# Altium schematic sheet Hall Effect IC.SchDoc
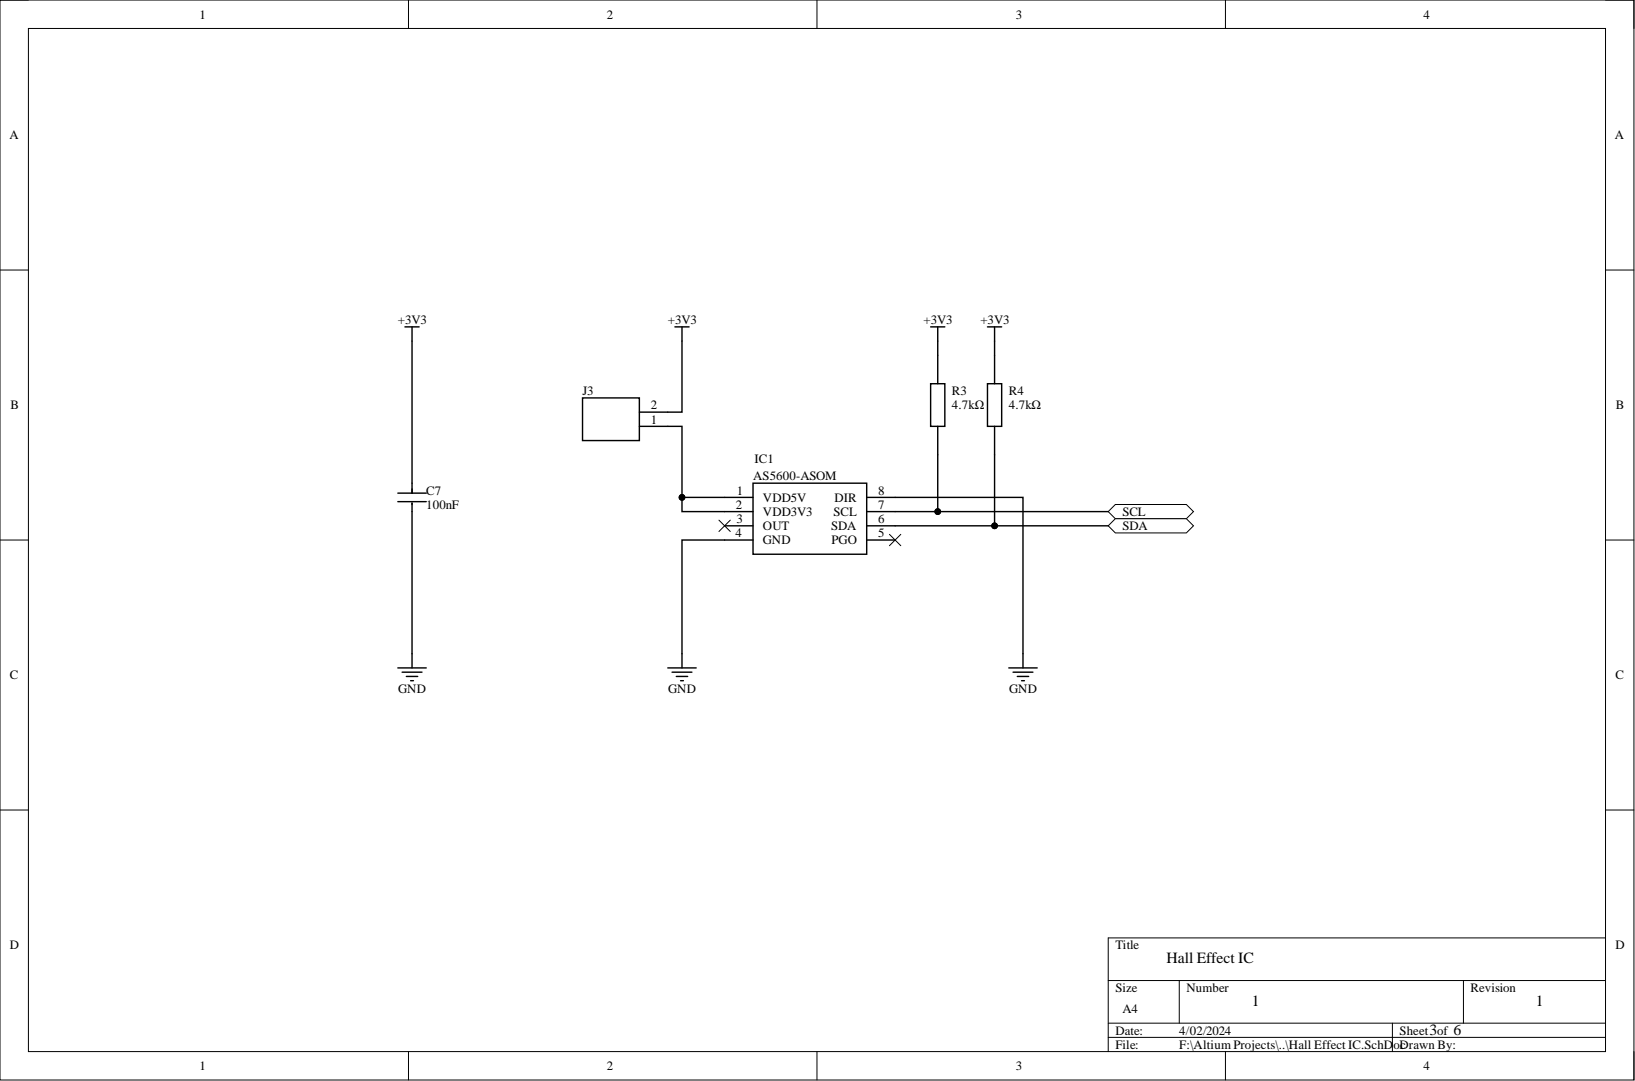
[diagram p0: Hall Effect IC.SchDoc]

## Parts
C7  value 100nF  [FP-C1206C-EB-MFG]  (hidden comment: C1206C104K5RAC7210)
IC1  AS5600-ASOM  [SOIC127P600X175-8N]
J3  87527-2  [HDRV2W66P0X254_1X2_467X244X1044P]  (value not drawn)
R3  value 4.7kΩ  [RESC3116X65N]  (hidden comment: CRCW12064K70FKEAHP)
R4  value 4.7kΩ  [RESC3116X65N]  (hidden comment: CRCW12064K70FKEAHP)

## Ports
SCL, SDA

## Nets
+3V3: C7.1 J3.2 R3.2 R4.2
GND: C7.2 IC1.4(GND) IC1.8(DIR)
SCL: IC1.7(SCL) R3.1 ; port SCL
SDA: IC1.6(SDA) R4.1 ; port SDA
NetIC1_1: IC1.1(VDD5V) IC1.2(VDD3V3) J3.1
NetIC1_3: IC1.3(OUT)
NetIC1_5: IC1.5(PGO)

## Library data of the parts
C7 = CMP-03020-003377-1: CAP CER 0.1UF 50V X7R 1206; Manufacturer: KEMET; Automotive Grade: Grade 0; Capacitance: 0.10 μF; Material: Ceramic; Temperature Coefficient: 15%; Tolerance: 0.1; Automotive: Yes; Category: Cap; Component Kind: Standard; ComponentLink2Description: Datasheet; ComponentLink2URL: https://content.kemet.com/datasheets/KEM_C1007_X8R_ULTRA_150C_SMD.pdf; Device Class L1: Passive Components; Device Class L2: Capacitors; Device Class L3: Ceramic Capacitors; Digikey Description: CAP CER 0.1UF 50V X7R 1206; Height: 0.88mm; Ipc Land Pattern Name: CAPC320160X78; Lead Free: Yes; Library Name: Altium Content Vault; Manufacturer Part Number: C1206C104K5RAC7210; Mouser Description: Multilayer Ceramic Capacitors MLCC - SMD/SMT 50volts 0.1uF X7R 10%; Octopart: https://octopart.com/c1206c104k5rac7210-kemet-3919856; Package: C1206C-EB; RoHS: TRUE; Temperature: -55°C to +150°C; Temperature Characteristic: X7R; Temperature Range High: +150°C; Temperature Range Low: -55°C; Voltage Rating: 50 V
IC1 = AS5600-ASOM: Integrated Circuit; Manufacturer_Name: ams OSRAM; Arrow Part Number: AS5600-ASOM; Arrow Price/Stock: https://www.arrow.com/en/products/as5600-asom/ams-ag?region=nac; Component Kind: Standard; Datasheet Link: https://datasheet.datasheetarchive.com/originals/distributors/DKDS-11/214624.pdf; Height: 1.75mm; Manufacturer_Part_Number: AS5600-ASOM; Mouser Part Number: 985-AS5600-ASOM; Mouser Price/Stock: https://www.mouser.co.uk/ProductDetail/ams/AS5600-ASOM?qs=KTMMzrZdriG6aU1h9lJCUQ%3D%3D
J3 = 87527-2: Power isolation jumper; Manufacturer_Name: TE Connectivity; Arrow Part Number: 87527-2; Arrow Price/Stock: https://www.arrow.com/en/products/87527-2/te-connectivity?region=nac; Component Kind: Standard; Datasheet Link: https://www.te.com/commerce/DocumentDelivery/DDEController?Action=showdoc&DocId=Customer+Drawing%7F87527%7FH%7Fpdf%7FEnglish%7FENG_CD_87527_H.pdf%7F87527-2; Height: 10.439mm; Manufacturer_Part_Number: 87527-2; Mouser Part Number: 571-875272; Mouser Price/Stock: https://www.mouser.co.uk/ProductDetail/TE-Connectivity/87527-2?qs=ZDXmSm13593hsB4j%252B6gMfg%3D%3D
R3 R4 = CRCW12064K70FKEAHP: Resistor; Manufacturer_Name: Vishay; Arrow Part Number: CRCW12064K70FKEAHP; Arrow Price/Stock: https://www.arrow.com/en/products/crcw12064k70fkeahp/vishay?region=nac; Component Kind: Standard; Datasheet Link: http://componentsearchengine.com/Datasheets/1/CRCW12064K70FKEAHP.pdf; Height: 0.65mm; Manufacturer_Part_Number: CRCW12064K70FKEAHP; Mouser Part Number: 71-CRCW12064K70FKEAH; Mouser Price/Stock: https://www.mouser.co.uk/ProductDetail/Vishay-Dale/CRCW12064K70FKEAHP?qs=k2%2FDWSARqgFo9mrkqxNreQ%3D%3D
